# Altium schematic sheet PowerBoard.SchDoc
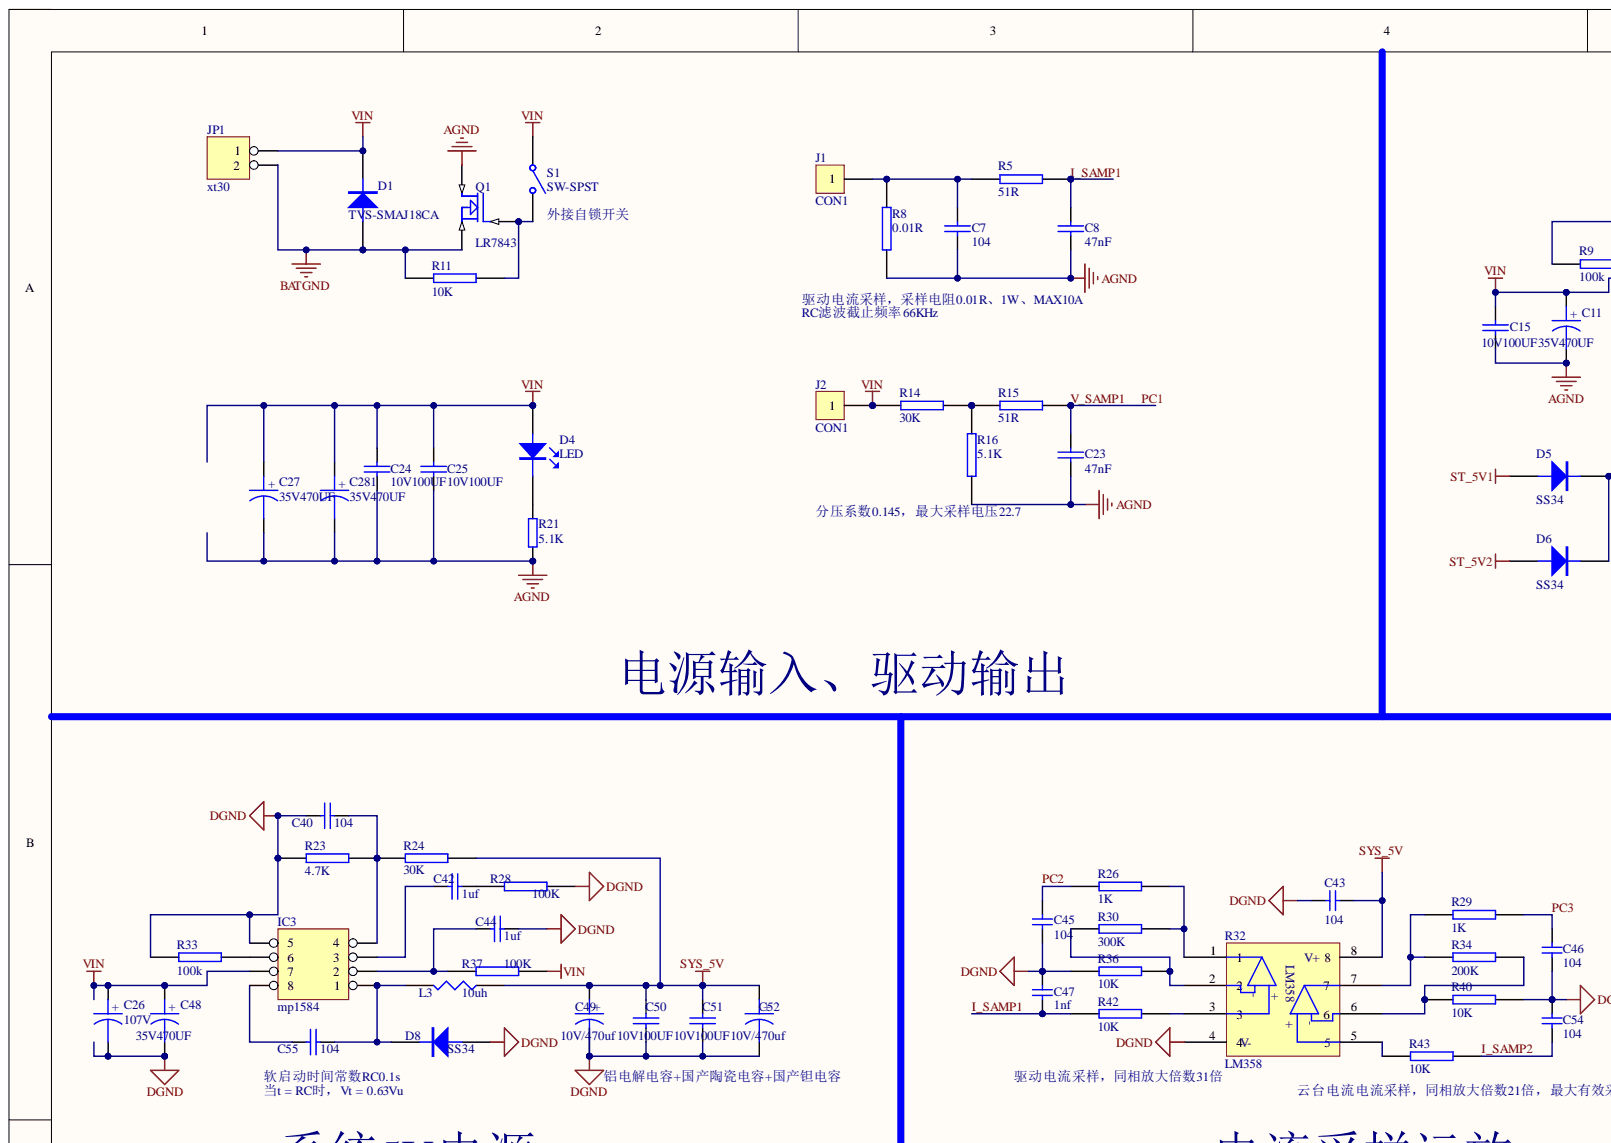
[diagram p0: PowerBoard.SchDoc, top left]
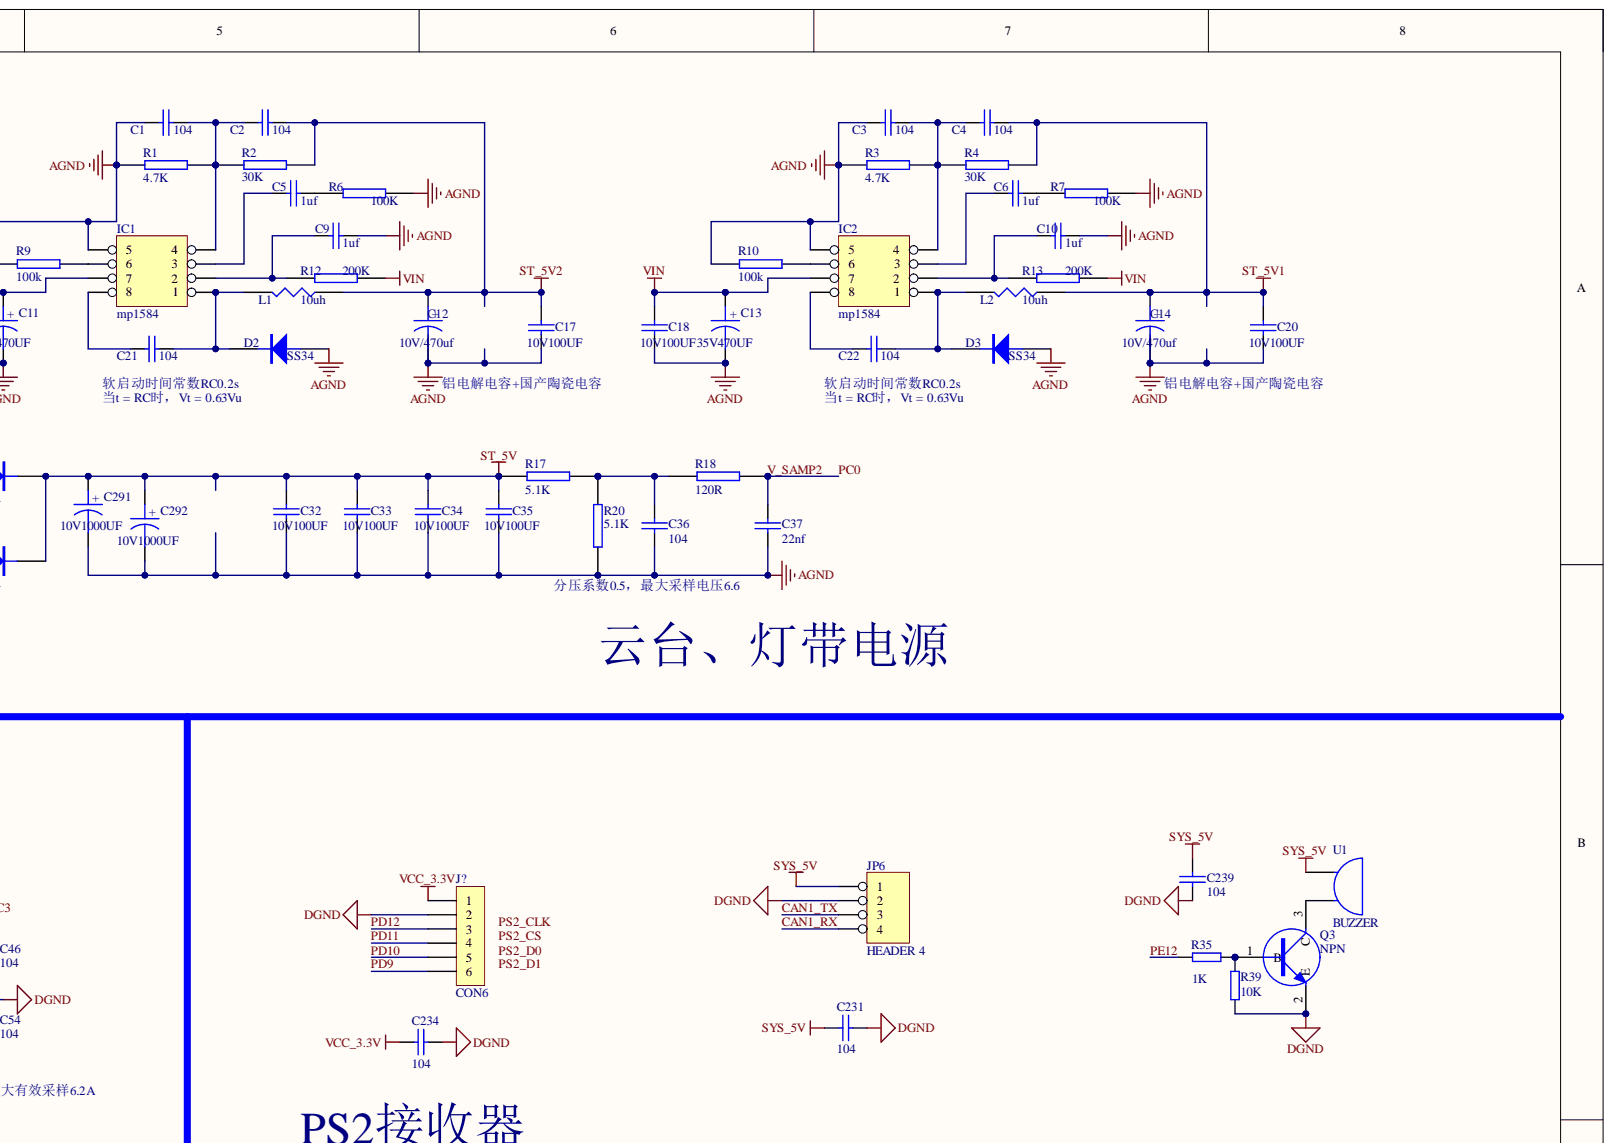
[diagram p1: PowerBoard.SchDoc, top right]
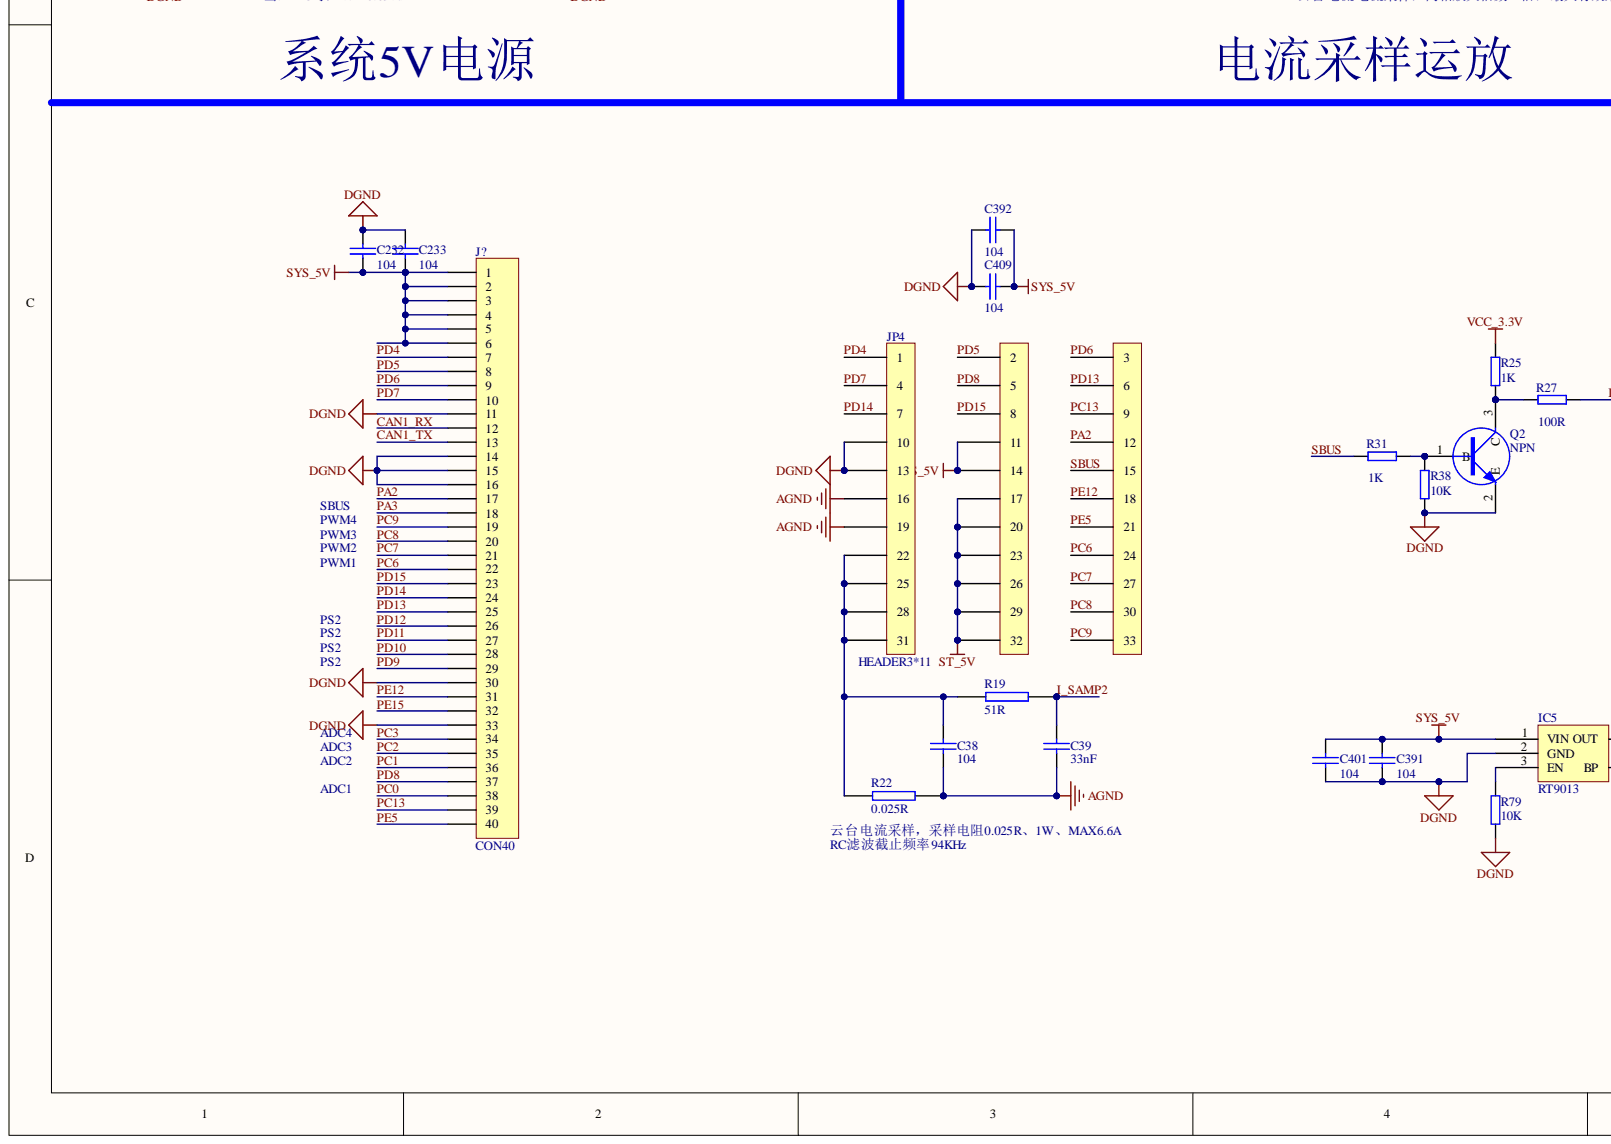
[diagram p2: PowerBoard.SchDoc, bottom left]
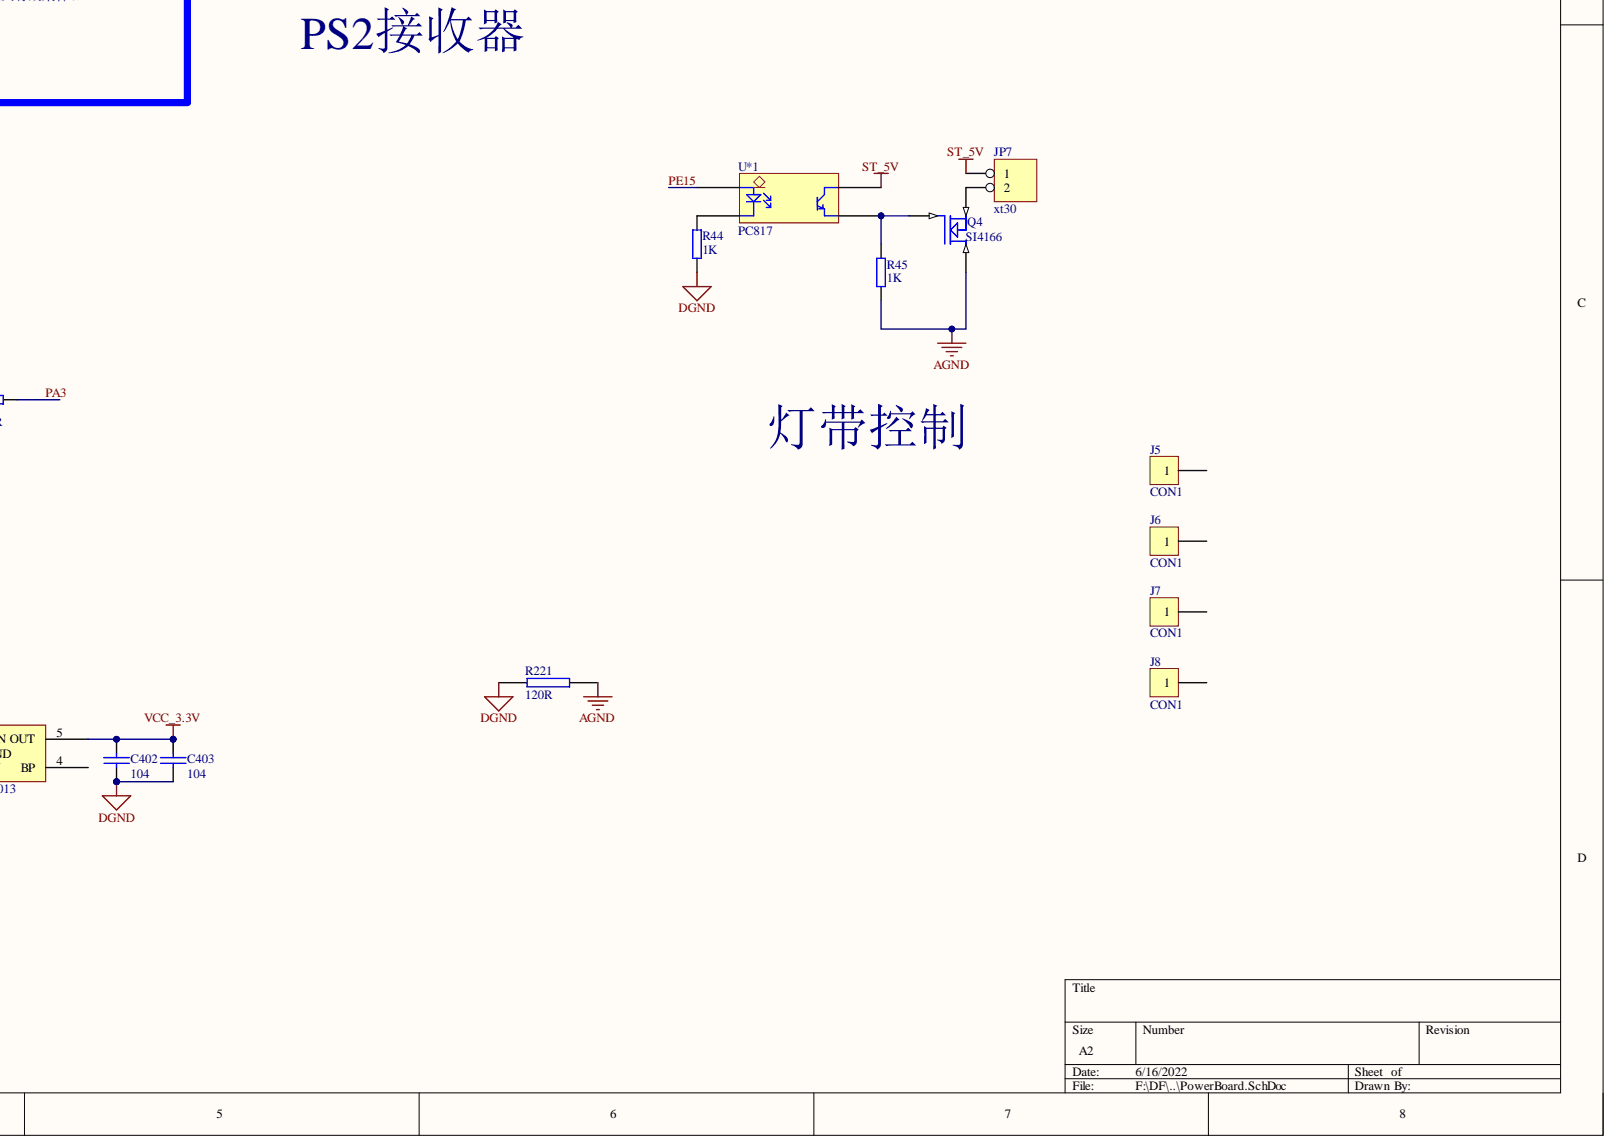
[diagram p3: PowerBoard.SchDoc, bottom right]

## Parts
C1  104  [LC-0603_C]
C2  104  [LC-0603_C]
C3  104  [LC-0603_C]
C4  104  [LC-0603_C]
C5  1uf  [LC-0603_C]
C6  1uf  [LC-0603_C]
C7  104  [LC-0603_C]
C8  47nF  [LC-0603_C]
C9  1uf  [LC-0603_C]
C10  1uf  [LC-0603_C]
C11  35V470UF  [10*16]
C12  10V/470uf  [6.3*7.7]
C13  35V470UF  [10*16]
C14  10V/470uf  [6.3*7.7]
C15  10V100UF  [LC-1210_C]
C17  10V100UF  [LC-1210_C]
C18  10V100UF  [LC-1210_C]
C20  10V100UF  [LC-1210_C]
C21  104  [LC-0603_C]
C22  104  [LC-0603_C]
C23  47nF  [LC-0603_C]
C24  10V100UF  [LC-1210_C]
C25  10V100UF  [LC-1210_C]
C26  107V  [7243]
C27  35V470UF  [10*16]
C32  10V100UF  [LC-1210_C]
C33  10V100UF  [LC-1210_C]
C34  10V100UF  [LC-1210_C]
C35  10V100UF  [LC-1210_C]
C36  104  [LC-0603_C]
C37  22nf  [LC-0603_C]
C38  104  [LC-0603_C]
C39  33nF  [LC-0603_C]
C40  104  [LC-0603_C]
C42  1uf  [LC-0603_C]
C43  104  [LC-0603_C]
C44  1uf  [LC-0603_C]
C45  104  [LC-0603_C]
C46  104  [LC-0603_C]
C47  1nf  [LC-0603_C]
C48  35V470UF  [10*16]
C49  10V/470uf  [6.3*7.7]
C50  10V100UF  [LC-1210_C]
C51  10V100UF  [LC-1210_C]
C52  10V/470uf  [6.3*7.7]
C54  104  [LC-0603_C]
C55  104  [LC-0603_C]
C231  104  [LC-0603_C]
C232  104  [LC-0603_C]
C233  104  [LC-0603_C]
C234  104  [LC-0603_C]
C239  104  [LC-0603_C]
C281  35V470UF  [10*16]
C291  10V1000UF  [8*12]
C292  10V1000UF  [8*12]
C391  104  [LC-0603_C]
C392  104  [LC-0603_C]
C401  104  [LC-0603_C]
C402  104  [LC-0603_C]
C403  104  [LC-0603_C]
C409  104  [LC-0603_C]
D1  TVS-SMAJ18CA  [M7]
D2  SS34  [M7]
D3  SS34  [M7]
D4  LED  [LC-0603_LED_S1]
D5  SS34  [M7]
D6  SS34  [M7]
D8  SS34  [M7]
IC1  mp1584  [SO-8]
IC2  mp1584  [SO-8]
IC3  mp1584  [SO-8]
IC5  RT9013  [SOT23-5]
J1  CON1  [TONGZHU_LUOSI-M3*5*6]
J2  CON1  [TONGZHU_LUOSI-M3*5*6]
J5  CON1  [TONGZHU-M2]
J6  CON1  [TONGZHU-M2]
J7  CON1  [TONGZHU-M2]
J8  CON1  [TONGZHU-M2]
J?  CON6  [GH1.25 - 6pin]
J?  CON40  [FPC0.5-40]
JP1  xt30  [XT30PW-M]
JP4  HEADER3*11  [SIP3*11]
JP6  HEADER 4  [GH1.25 - 4Pin]
JP7  xt30  [XT30PW-M]
L1  10uh  [LC-0630-C]
L2  10uh  [LC-0630-C]
L3  10uh  [LC-0630-C]
Q1  LR7843  [TO-252]
Q2  NPN  [LC-SOT-23(SOT-23-3)]
Q3  NPN  [LC-SOT-23(SOT-23-3)]
Q4  SI4166  [SO-8-MOS]
R1  4.7K  [LC-0603_R]
R2  30K  [LC-0603_R]
R3  4.7K  [LC-0603_R]
R4  30K  [LC-0603_R]
R5  51R  [LC-0603_R]
R6  100K  [LC-0603_R]
R7  100K  [LC-0603_R]
R8  0.01R  [LC-1206_R]
R9  100k  [LC-0603_R]
R10  100k  [LC-0603_R]
R11  10K  [LC-0603_R]
R12  200K  [LC-0603_R]
R13  200K  [LC-0603_R]
R14  30K  [LC-0603_R]
R15  51R  [LC-0603_R]
R16  5.1K  [LC-0603_R]
R17  5.1K  [LC-0603_R]
R18  120R  [LC-0603_R]
R19  51R  [LC-0603_R]
R20  5.1K  [LC-0603_R]
R21  5.1K  [LC-0603_R]
R22  0.025R  [LC-1206_R]
R23  4.7K  [LC-0603_R]
R24  30K  [LC-0603_R]
R25  1K  [LC-0603_R]
R26  1K  [LC-0603_R]
R27  100R  [LC-0603_R]
R28  100K  [LC-0603_R]
R29  1K  [LC-0603_R]
R30  300K  [LC-0603_R]
R31  1K  [LC-0603_R]
R32  LM358  [SO-8]
R33  100k  [LC-0603_R]
R34  200K  [LC-0603_R]
R35  1K  [LC-0603_R]
R36  10K  [LC-0603_R]
R37  100K  [LC-0603_R]
R38  10K  [LC-0603_R]
R39  10K  [LC-0603_R]
R40  10K  [LC-0603_R]
R42  10K  [LC-0603_R]
R43  10K  [LC-0603_R]
R44  1K  [LC-0603_R]
R45  1K  [LC-0603_R]
R79  10K  [LC-0603_R]
R221  120R  [LC-1206_R]
S1  SW-SPST  [GH1.25 - 2Pin]
U1  BUZZER  [FMQ9*5]
U*1  PC817  [SOP4]

## Nets
AGND: C1.1 C3.1 C7.2 C8.2 C9.2 C10.2 C11.2 C12.2 C13.2 C14.2 C15.1 C17.1 C18.1 C20.1 C23.2 C24.1 C25.1 C27.2 C32.1 C33.1 C34.1 C35.1 C36.2 C37.2 C38.2 C39.2 C281.2 C291.2 C292.2 D2.1 D3.1 IC1.5 IC2.5 JP4.16 JP4.19 Q1.2 Q4.3 R1.2 R3.2 R6.1 R7.1 R8.2 R9.2 R10.2 R16.1 R20.1 R21.1 R22.1 R45.1 R221.1
BATGND: D1.1 JP1.2 Q1.3 R11.2
DGND: C26.2 C40.1 C43.2 C44.2 C45.1 C46.1 C47.1 C48.2 C49.2 C50.1 C51.1 C52.2 C54.1 C231.2 C232.2 C233.2 C234.2 C239.1 C391.2 C392.1 C401.2 C402.2 C403.2 C409.1 D8.1 IC3.5 IC5.2(GND) J?.2 J?.11 J?.14 J?.15 J?.16 J?.30 J?.33 JP4.10 JP4.13(SYS_5V) JP6.2 Q2.2 Q3.2 R23.2 R28.1 R32.4 R33.2 R36.2 R38.1 R39.1 R40.2 R44.1 R79.1 R221.2
ST_5V: C32.2 C33.2 C34.2 C35.2 C291.1 C292.1 D5.2 D6.2 JP4.17 JP4.20 JP4.23 JP4.26 JP4.29 JP4.32 JP7.1 R17.1 U*1.4
ST_5V1: C4.2 C14.1 C20.2 D5.1 L2.1 R4.2
ST_5V2: C2.2 C12.1 C17.2 D6.1 L1.1 R2.2
SYS_5V: C43.1 C49.1 C50.2 C51.2 C52.1 C231.1 C232.1 C233.1 C239.2 C391.1 C392.2 C401.1 C409.2 IC5.1(VIN) J?.1 J?.2 J?.3 J?.4 J?.5 J?.6 JP4.11 JP4.14 JP6.1 L3.1 R24.2 R32.8 U1.1
VCC_3.3V: C234.1 C402.1 C403.1 IC5.5(OUT) J?.1 R25.2
VIN: C11.1 C13.1 C15.2 C18.2 C24.2 C25.2 C26.1 C27.1 C48.1 C281.1 D1.2 D4.A IC1.7 IC2.7 IC3.7 J2.1 JP1.1 R12.1 R13.1 R14.1 R37.1 S1.1
CAN1_RX: J?.12 JP6.4
CAN1_TX: J?.13 JP6.3
I_SAMP1: C8.1 C47.2 R5.2 R42.1
I_SAMP2: C39.1 C54.2 R19.2 R43.1
PA2: J?.17 JP4.12
PA3: J?.18 R27.2
PC0: C37.1 J?.38 R18.2
PC1: C23.1 J?.36 R15.2
PC2: C45.2 J?.35 R26.1
PC3: C46.2 J?.34 R29.2
PC6: J?.22 JP4.24
PC7: J?.21 JP4.27
PC8: J?.20 JP4.30
PC9: J?.19 JP4.33
PC13: J?.39 JP4.9
PD4: J?.7 JP4.1
PD5: J?.8 JP4.2
PD6: J?.9 JP4.3
PD7: J?.10 JP4.4
PD8: J?.37 JP4.5
PD9: J?.6 J?.29
PD10: J?.5 J?.28
PD11: J?.4 J?.27
PD12: J?.3 J?.26
PD13: J?.25 JP4.6
PD14: J?.24 JP4.7
PD15: J?.23 JP4.8
PE5: J?.40 JP4.21
PE12: J?.31 JP4.18 R35.1
PE15: J?.32 U*1.1
SBUS: JP4.15 R31.1
NetC1_2: C1.2 C2.1 IC1.4 R1.1 R2.1
NetC3_2: C3.2 C4.1 IC2.4 R3.1 R4.1
NetC5_1: C5.1 IC1.3
NetC5_2: C5.2 R6.2
NetC6_1: C6.1 IC2.3
NetC6_2: C6.2 R7.2
NetC7_1: C7.1 J1.1 R5.1 R8.1
NetC9_1: C9.1 IC1.2 R12.2
NetC10_1: C10.1 IC2.2 R13.2
NetC21_1: C21.1 IC1.8
NetC21_2: C21.2 D2.2 IC1.1 L1.2
NetC22_1: C22.1 IC2.8
NetC22_2: C22.2 D3.2 IC2.1 L2.2
NetC36_1: C36.1 R17.2 R18.1 R20.2
NetC38_1: C38.1 JP4.22 JP4.25 JP4.28 JP4.31 R19.1 R22.2
NetC40_2: C40.2 IC3.4 R23.1 R24.1
NetC42_1: C42.1 IC3.3
NetC42_2: C42.2 R28.2
NetC44_1: C44.1 IC3.2 R37.2
NetC55_1: C55.1 IC3.8
NetC55_2: C55.2 D8.2 IC3.1 L3.2
NetD4_K: D4.K R21.2
NetIC1_6: IC1.6 R9.1
NetIC2_6: IC2.6 R10.1
NetIC3_6: IC3.6 R33.1
NetIC5_3: IC5.3(EN) R79.2
NetJP7_2: JP7.2 Q4.2
NetQ1_1: Q1.1 R11.1 S1.2
NetQ2_1: Q2.1 R31.2 R38.2
NetQ2_3: Q2.3 R25.1 R27.1
NetQ3_1: Q3.1 R35.2 R39.2
NetQ3_3: Q3.3 U1.2
NetQ4_1: Q4.1 R45.2 U*1.3
NetR14_2: R14.2 R15.1 R16.2
NetR26_2: R26.2 R30.2 R32.1
NetR29_1: R29.1 R32.7 R34.1
NetR30_1: R30.1 R32.2 R36.1
NetR32_3: R32.3 R42.2
NetR32_5: R32.5 R43.2
NetR32_6: R32.6 R34.2 R40.1
NetR44_2: R44.2 U*1.2
PS2_CLK:
PS2_CS:
PS2_D0:
PS2_D1:

Not connected: IC5 4(BP); J5 1; J6 1; J7 1; J8 1

## Library data of the parts
C1-C6 C9 C10 C15 C17 C18 C20-C22 C24 C25 C32-C35 C40 C42 C44 C50 C51 C55 C231-C234 C239 = CAP: Capacitor; Component Kind: Standard
C7 C8 C23 C36-C39 C391 C392 C401-C403 C409 = CAP: Component Kind: Standard
C11-C14 C26 C27 C48 C49 C52 C281 C291 C292 = CAPACITOR POL: Capacitor; Component Kind: Standard
C43 C45-C47 C54 = CAP1: Capacitor; ERP: 0103020075; PCB_Layout: Top Layer SMC; TOL: 10%; Tolerate: 50V; Value: 100nF; Component Kind: Standard; Revision: 2012-3-28; Publisher: ZL_A0OFFICE
D1 = DIODE: 瞬态抑制二极管; Component Kind: Standard
D2 D3 D5 D6 D8 = DIODE: Component Kind: Standard; Description: Diode
IC1-IC3 = HEADER 4X2: Component Kind: Standard
IC5 = LDO-5: Component Kind: Standard
J1 J2 J5-J8 = CON1: Connector; Component Kind: Standard; Library Name: 自己的Miscellaneous Devices.lib
J? = CON6: Connector; Component Kind: Standard; Library Name: 自己的Miscellaneous Devices.lib
J? = CON40: Connector; Component Kind: Standard; Library Name: 自己的Miscellaneous Devices.lib
JP1 JP7 = HEADER 2: Component Kind: Standard; Library Name: 自己的Miscellaneous Devices.lib
L1-L3 = RES1: Component Kind: Standard
Q1 Q4 = MOSFET N: Component Kind: Standard
  Q1: Library Name: 自己的Miscellaneous Devices.lib
Q2 Q3 = NPN: NPN Transistor; Component Kind: Standard; Library Name: 自己的Miscellaneous Devices.lib
R1-R20 R22-R24 R26 R28-R30 R33 R34 R36 R37 R40 R42 R43 R221 = RES2: Component Kind: Standard
R21 R25 R27 R31 R35 R38 R39 R44 R45 R79 = R: Component Kind: Standard
  R25 R27 R31 R35 R38 R39 R44 R45: Library Name: 自己的Miscellaneous Devices.lib
S1 = SW-SPST: Single-Pole, Single-Throw Switch; Component Kind: Standard
U*1 = PC817: 光耦; Component Kind: Standard; Library Name: 自己的Miscellaneous Devices.lib
D4 = LED: Component Kind: Standard; Library Name: 自己的Miscellaneous Devices.lib
JP4 = HEADER3*11: Component Kind: Standard; Library Name: 自己的Miscellaneous Devices.lib
JP6 = HEADER 4: Component Kind: Standard; Library Name: 自己的Miscellaneous Devices.lib
R32 = LM358: Component Kind: Standard; Library Name: 自己的Miscellaneous Devices.lib
U1 = BUZZER: Component Kind: Standard; Description: Buzzer; Library Name: 自己的Miscellaneous Devices.lib
